# Altium schematic sheet Sonic Sandbox.SchDoc
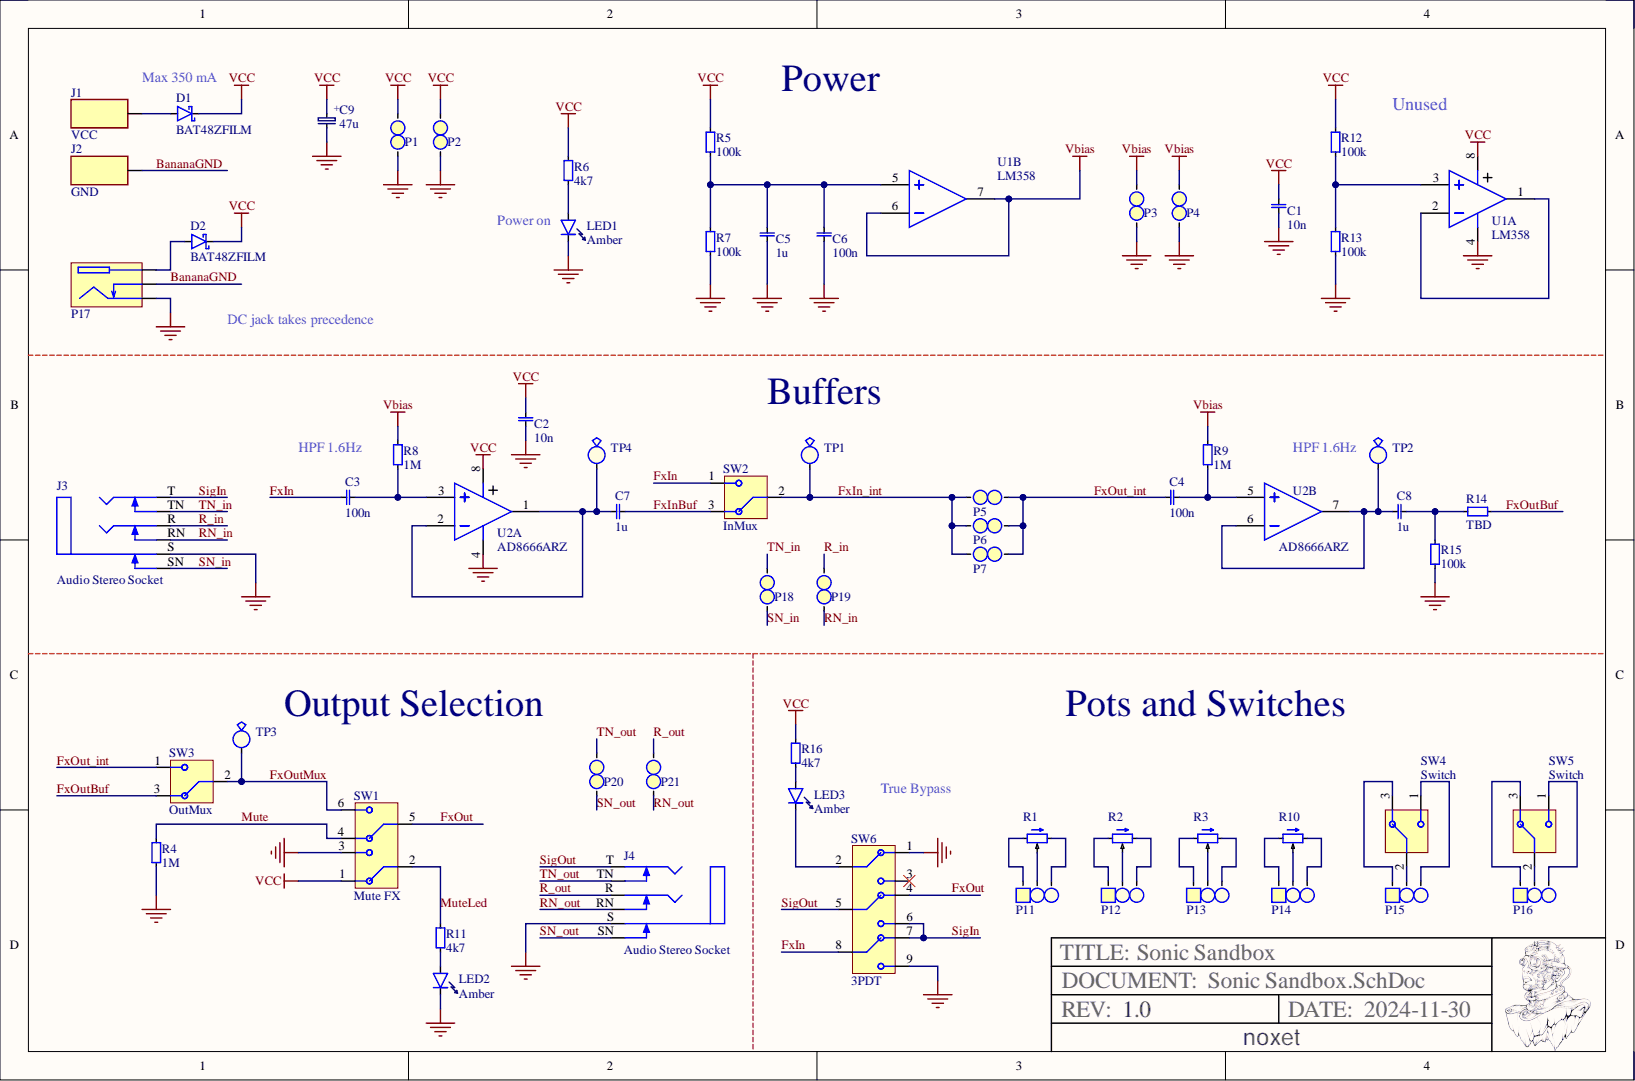
[diagram p0: Sonic Sandbox.SchDoc]

## Parts
C1  value 10n  [0805, cap]  (hidden comment: 0805, capacitor)
C2  value 10n  [0805, cap]  (hidden comment: 0805, capacitor)
C3  value 100n  [0805, cap]  (hidden comment: 0805, capacitor)
C4  value 100n  [0805, cap]  (hidden comment: 0805, capacitor)
C5  value 1u  [0805, cap]  (hidden comment: 0805, capacitor)
C6  value 100n  [0805, cap]  (hidden comment: 0805, capacitor)
C7  value 1u  [0805, cap]  (hidden comment: 0805, capacitor)
C8  value 1u  [0805, cap]  (hidden comment: 0805, capacitor)
C9  value 47u  [Cap, SMD, Polar, 5.3x5.3mm]  (hidden comment: Capacitor, TH 2mm)
D1  BAT48ZFILM  [SOD123]
D2  BAT48ZFILM  [SOD123]
J1  VCC  [Banana Conn VCC]
J2  GND  [Banana Conn GND]
J3  Audio Stereo Socket  [6.3mm Audio Socket PCB]
J4  Audio Stereo Socket  [6.3mm Audio Socket PCB]
LED1  Amber  [0805_led]
LED2  Amber  [0805_led]
LED3  Amber  [0805_led]
P1  1x2 Pin Heade Straight  [padtwo_L]  (value not drawn)
P2  1x2 Pin Heade Straight  [padtwo_L]  (value not drawn)
P3  1x2 Pin Heade Straight  [padtwo_L]  (value not drawn)
P4  1x2 Pin Heade Straight  [padtwo_L]  (value not drawn)
P5  1x2 Pin Heade Straight  [padtwo_L]  (value not drawn)
P6  1x2 Pin Heade Straight  [padtwo_L]  (value not drawn)
P7  1x2 Pin Heade Straight  [padtwo_L]  (value not drawn)
P11  1x3 Pin Header  [padThree_L]  (value not drawn)
P12  1x3 Pin Header  [padThree_L]  (value not drawn)
P13  1x3 Pin Header  [padThree_L]  (value not drawn)
P14  1x3 Pin Header  [padThree_L]  (value not drawn)
P15  1x3 Pin Header  [padThree_L]  (value not drawn)
P16  1x3 Pin Header  [padThree_L]  (value not drawn)
P17  Power socket  [DC Plug PCB]  (value not drawn)
P18  1x2 Pin Heade Straight  [padtwo_L]  (value not drawn)
P19  1x2 Pin Heade Straight  [padtwo_L]  (value not drawn)
P20  1x2 Pin Heade Straight  [padtwo_L]  (value not drawn)
P21  1x2 Pin Heade Straight  [padtwo_L]  (value not drawn)
R1  Potentiometer  [RK09L_15mm]  (value not drawn)
R2  Potentiometer  [RK09L_15mm]  (value not drawn)
R3  Potentiometer  [RK09L_15mm]  (value not drawn)
R4  value 1M  [0805]  (hidden comment: General resistor)
R5  value 100k  [0805]  (hidden comment: General resistor)
R6  value 4k7  [0805]  (hidden comment: General resistor)
R7  value 100k  [0805]  (hidden comment: General resistor)
R8  value 1M  [0805]  (hidden comment: General resistor)
R9  value 1M  [0805]  (hidden comment: General resistor)
R10  Potentiometer  [RK09L_15mm]  (value not drawn)
R11  value 4k7  [0805]  (hidden comment: General resistor)
R12  value 100k  [0805]  (hidden comment: General resistor)
R13  value 100k  [0805]  (hidden comment: General resistor)
R14  value TBD  [0805]  (hidden comment: General resistor)
R15  value 100k  [0805]  (hidden comment: General resistor)
R16  value 4k7  [0805]  (hidden comment: General resistor)
SW1  Mute FX  [SW_DPDT_MTS-202-A2]
SW2  InMux  [SW_SPDT_SMTS-102-A2]
SW3  OutMux  [SW_SPDT_SMTS-102-A2]
SW4  Switch  [SW_SPDT_SMTS-102-A2]
SW5  Switch  [SW_SPDT_SMTS-102-A2]
SW6  3PDT  [SW_3PDT_PBS-24-302]
TP1  Test Point  [TESTPOINT_SMD]  (value not drawn)
TP2  Test Point  [TESTPOINT_SMD]  (value not drawn)
TP3  Test Point  [TESTPOINT_SMD]  (value not drawn)
TP4  Test Point  [TESTPOINT_SMD]  (value not drawn)
U1  LM358  [SOIC8]  (parts A,B)
U2  AD8666ARZ  [SOIC8]  (parts A,B)

## Nets
GND: C1.2 C2.2 C5.2 C6.2 C9.1 J3.S J4.S LED1.0 LED2.0 P1.2 P2.2 P3.2 P4.2 P17.2 R4.1 R7.1 R13.1 R15.2 SW1.3 SW6.1 SW6.9 U1.4 U2.4
Vbias: P3.1 P4.1 R8.2 R9.2 U1.6 U1.7
VCC: C1.1 C2.1 C9.2 D1.1 D2.1 P1.1 P2.1 R5.2 R6.2 R12.2 R16.2 SW1.1 U1.8 U2.8
BananaGND: J2.1 P17.3
FxIn: C3.2 SW2.1 SW6.8
FxIn_int: P5.2 P6.2 P7.2 SW2.2 TP1.0
FxInBuf: C7.1 SW2.3
FxOut: SW1.5 SW6.4
FxOut_int: C4.2 P5.1 P6.1 P7.1 SW3.1
FxOutBuf: R14.1 SW3.3
FxOutMux: SW1.6 SW3.2 TP3.0
Mute: R4.2 SW1.4
MuteLed: R11.2 SW1.2
R_in: J3.R P19.1
R_out: J4.R P21.1
RN_in: J3.RN P19.2
RN_out: J4.RN P21.2
SigIn: J3.T SW6.6 SW6.7
SigOut: J4.T SW6.5
SN_in: J3.SN P18.2
SN_out: J4.SN P20.2
TN_in: J3.TN P18.1
TN_out: J4.TN P20.1
NetC3_1: C3.1 R8.1 U2.3
NetC4_1: C4.1 R9.1 U2.5
NetC5_1: C5.1 C6.1 R5.1 R7.2 U1.5
NetC7_2: C7.2 TP4.0 U2.1 U2.2
NetC8_1: C8.1 R14.2 R15.1
NetC8_2: C8.2 TP2.0 U2.6 U2.7
NetD1_2: D1.2 J1.1
NetD2_2: D2.2 P17.1
NetLED1_1: LED1.1 R6.1
NetLED2_1: LED2.1 R11.1
NetLED3_0: LED3.0 SW6.2
NetLED3_1: LED3.1 R16.1
NetP11_1: P11.1 R1.1
NetP11_2: P11.2 R1.2
NetP11_3: P11.3 R1.3
NetP12_1: P12.1 R2.1
NetP12_2: P12.2 R2.2
NetP12_3: P12.3 R2.3
NetP13_1: P13.1 R3.1
NetP13_2: P13.2 R3.2
NetP13_3: P13.3 R3.3
NetP14_1: P14.1 R10.1
NetP14_2: P14.2 R10.2
NetP14_3: P14.3 R10.3
NetP15_1: P15.1 SW4.3
NetP15_2: P15.2 SW4.2
NetP15_3: P15.3 SW4.1
NetP16_1: P16.1 SW5.3
NetP16_2: P16.2 SW5.2
NetP16_3: P16.3 SW5.1
NetR12_1: R12.1 R13.2 U1.3
NetU1_1: U1.1 U1.2

Not connected: SW6 3

## Library data of the parts
C9 = Capacitor, TH 2mm: Capacitor TH 2mm; Component Kind: Standard; Library Name: jclib.IntLib
D1 D2 = BAT48ZFILM: Rectifier Diode, Schottky, 1 Phase, 1 Element, 0.35A, 40V V(RRM), Silicon; Manufacturer: STMicroelectronics; Forward Voltage: 250mV; Max Output current: 350mA; Non-rep Pk Forward Current-Max: 2A; Number of Elements: 1; Package Body Material: Plastic; Qualification Status: Not Qualified; Time @ Peak Reflow Temperature-Max (s): 30s; Category: Rectifier Diodes; Component Kind: Standard; ComponentLink1Description: https://datasheet.ciiva.com:443/pdfs/VipMasterIC/IC/SGST/SGSTS30425/SGSTS30425-1.pdf?src-supplier=IHS+Markit; ComponentLink1URL: https://datasheet.ciiva.com:443/pdfs/VipMasterIC/IC/SGST/SGSTS30425/SGSTS30425-1.pdf?src-supplier=IHS+Markit; ComponentLink2Description: https://datasheet.ciiva.com/16421/cd00130229-16421639.pdf?src-supplier=Future+Electronics; ComponentLink2URL: https://datasheet.ciiva.com/16421/cd00130229-16421639.pdf?src-supplier=Future+Electronics; ComponentLink3Description: https://datasheet.ciiva.com/915/cd00130229-915584.pdf?src-supplier=Digikey; ComponentLink3URL: https://datasheet.ciiva.com/915/cd00130229-915584.pdf?src-supplier=Digikey; ComponentLink4Description: https://datasheet.ciiva.com/915/cd00130229-915585.pdf?src-supplier=Digikey; ComponentLink4URL: https://datasheet.ciiva.com/915/cd00130229-915585.pdf?src-supplier=Digikey; ComponentLink5Description: https://datasheet.ciiva.com/915/cd00130229-915586.pdf?src-supplier=Digikey; ComponentLink5URL: https://datasheet.ciiva.com/915/cd00130229-915586.pdf?src-supplier=Digikey; ComponentLink6Description: https://datasheet.ciiva.com/4714/cd00130229-4714093.pdf?src-supplier=Mouser; ComponentLink6URL: https://datasheet.ciiva.com/4714/cd00130229-4714093.pdf?src-supplier=Mouser; Diode Element Material: SILICON; Diode Type: RECTIFIER; JESD-30 Code: R-PDSO-G2; JESD-609 Code: e3; Manufacturer Part Number: BAT48ZFILM; Max Operating Temperature: 150°C; Package Shape: Rectangular; Package Style: SMALL OUTLINEMeter; Peak Reflow Temperature (Cel): 260°C; Pins: 2; Pricing: 250=2,567, 500=2,311, 1250=2,081, 2500=1,976 (SEK); Reach SVHC Compliant: No; Rep Pk Reverse Voltage-Max: 40V; RoHS: Yes; RoHS Compliant: Yes; Stock: 13200; Supplier: Rs; Supplier Part Number: 7146853P; Surface Mount: Yes; Technology: SCHOTTKY; Terminal Finish: Tin; Terminal Form: Gull Wing; Terminal Position: DUAL; Library Name: jclib.IntLib
J1 J2 = Banana conn: Banana Connector 571-0100; Manufacturer_Name: Deltron; Component Kind: Standard; Datasheet Link: https://www.dem-uk.com/deltron-components/Data/Product_Downloads/deltron-571-0100-4mm-90deg-single-socket-data.pdf; Height: 12.4mm; Manufacturer_Part_Number: 571-0100; Mouser Part Number: 164-6218; Mouser Price/Stock: https://www.mouser.com/Search/Refine.aspx?Keyword=164-6218; Library Name: jclib.IntLib
J3 J4 = 6.3mm Audio Socket: Component Kind: Standard; Library Name: jclib.IntLib
LED1-LED3 = 0805, LED: Value: 0805 LED; Component Kind: Standard; Library Name: jclib.IntLib
P1-P7 P18-P21 = 1x2 Pin Heade Straight: 2.54 mm, TH; Value: 1x2 Pin Header; Component Kind: Standard; Library Name: jclib.IntLib
P11-P16 = 1x3 Pin Header: 2.54 mm; Component Kind: Standard; Value: 1x3 Pin Header; Library Name: jclib.IntLib
R1-R3 R10 = Potentiometer: Value: 0; Component Kind: Standard; Description: Potentiometer; ELFA: 300-08-353; Library Name: jclib.SchLib
R4-R9 R11-R16 = resistor: Component Kind: Standard; Library Name: jclib.IntLib
SW1 = Switch DPDT: Toggle switch DPDT; Component Kind: Standard; Library Name: jclib.IntLib
SW2-SW5 = Switch SPDT: Toggle switch SPDT; Component Kind: Standard; Library Name: jclib.IntLib
SW6 = Switch 3PDT: Toggle switch 3PDT; Component Kind: Standard; Library Name: jclib.IntLib
U1 = LM358DR: IC OPAMP GP 700KHZ 8SOIC; Manufacturer: Texas Instruments; Amplifier Type: GENERAL PURPOSE; Common Mode Rejection Ratio: 65dB; Dual Supply Voltage: 9V; Input Bias Current: 250nA; Input Offset Voltage (Vos): 7mV; Max Dual Supply Voltage: 16V; Max Supply Voltage: 32V; Min Dual Supply Voltage: 1.5V; Min Supply Voltage: 3V; Nominal Supply Current: 1.2mA; Number of Amplifiers: 2; Operating Supply Current: 1mA; Output Current: 30mA; Slew Rate: 0.3V/µs; Voltage Gain: 100dB; Bandwidth: 700kHz; Case/Package: SOIC; Category: Amplifiers - Op Amps, Buffer, Instrumentation; Component Kind: Standard; ComponentLink1Description: https://datasheet.ciiva.com/1795/lm358-1795488.pdf?src-supplier=Digi-Key; ComponentLink1URL: https://datasheet.ciiva.com/1795/lm358-1795488.pdf?src-supplier=Digi-Key; ComponentLink2Description: https://datasheet.ciiva.com/27056/2016330-27056228.pdf?src-supplier=Jameco; ComponentLink2URL: https://datasheet.ciiva.com/27056/2016330-27056228.pdf?src-supplier=Jameco; ComponentLink3Description: https://datasheet.ciiva.com/7709/1874566-7709823.pdf?src-supplier=Element14; ComponentLink3URL: https://datasheet.ciiva.com/7709/1874566-7709823.pdf?src-supplier=Element14; ComponentLink4Description: https://datasheet.ciiva.com/46385/1874566-46385851.pdf?src-supplier=Element14; ComponentLink4URL: https://datasheet.ciiva.com/46385/1874566-46385851.pdf?src-supplier=Element14; ComponentLink5Description: https://datasheet.ciiva.com/46390/1874566-46390414.pdf?src-supplier=Element14; ComponentLink5URL: https://datasheet.ciiva.com/46390/1874566-46390414.pdf?src-supplier=Element14; ComponentLink6Description: https://datasheet.ciiva.com/1795/lm358-1795487.pdf?src-supplier=Digi-Key; ComponentLink6URL: https://datasheet.ciiva.com/1795/lm358-1795487.pdf?src-supplier=Digi-Key; ComponentLink7Description: https://datasheet.ciiva.com/1795/lm358-1795489.pdf?src-supplier=Digi-Key; ComponentLink7URL: https://datasheet.ciiva.com/1795/lm358-1795489.pdf?src-supplier=Digi-Key; ComponentLink8Description: https://datasheet.ciiva.com/2973/lm358a-2973386.pdf?src-supplier=Avnet; ComponentLink8URL: https://datasheet.ciiva.com/2973/lm358a-2973386.pdf?src-supplier=Avnet; Contact Plating: Gold; Gain Bandwidth Product: 700kHz; Height: 1.58mm; Lead Free: Lead Free; Length: 4.9mm; Manufacturer Part Number: LM358DR; Max Operating Temperature: 70°C; Min Operating Temperature: 0°C; Mounting Technology: Surface Mount; Number of Channels: 2; Number of Circuits: 2; Number of Elements: 2; Operating Supply Voltage: 16V; Output Current per Channel: 30mA; Package Quantity: 2500; Packaging: Tape and Reel; Pins: 8; Power Supply Rejection Ratio (PSRR): 65dB; Pricing 1: 1=0,37000, 10=0,29900, 50=0,29900, 100=0,18500, 1000=0,11500, 10000=0,10400 (USD); Radiation Hardening: No; Reach SVHC Compliant: Yes; RoHS: Yes; RoHS Compliant: Yes; Series: -; Stock 1: 281120; Supplier 1: Mouser; Supplier Part Number 1: 595-LM358DR; Supply Current: 1.2mA; Technology: BIPOLAR; Unity Gain Bandwidth Product: 700kHz; Width: 3.91mm; Library Name: jclib.IntLib
U2 = AD8666ARZ: Operational Amplifier, 2 Func, 5000uV Offset-Max, CMOS, PDSO8; Manufacturer: Analog Devices; Architecture: VOLTAGE-FEEDBACK; Average Bias Current-Max (IIB): 550pA; Bias Current-Max (IIB) @25C: 1pA; Channels: 2; Common-mode Reject Ratio-Nom: 100dB; Frequency Compensation: YES; Input Offset Voltage-Max: 5mV; Low-Offset: No; Max Supply Current: 4mA; Neg Supply Voltage Limit-Max: 0V; Nominal Supply Voltage: 5V; Package Body Material: Plastic; Package Equivalence Code: SOP8,.25; Power Supplies: +-2.5/+-8/5/16V; Qualification Status: Not Qualified; Slew Rate: 3.5V/µs; Supply Voltage Limit-Max: 18V; Time @ Peak Reflow Temperature-Max (s): 30s; Unity Gain BW-Nom: 4MHz; Voltage Gain-Min: 68000; Amplifier Type: OPERATIONAL AMPLIFIER; Case/Package: SOP; Category: Amplifiers - Op Amps, Buffer, Instrumentation; Component Kind: Standard; ComponentLink1Description: https://datasheet.ciiva.com:443/pdfs/VipMasterIC/IC/ANDI/ANDIS11402/ANDIS11402-1.pdf?src-supplier=IHS+Markit; ComponentLink1URL: https://datasheet.ciiva.com:443/pdfs/VipMasterIC/IC/ANDI/ANDIS11402/ANDIS11402-1.pdf?src-supplier=IHS+Markit; ComponentLink2Description: https://datasheet.ciiva.com/1487/1481546-1487312.pdf?src-supplier=Element14; ComponentLink2URL: https://datasheet.ciiva.com/1487/1481546-1487312.pdf?src-supplier=Element14; ComponentLink3Description: https://datasheet.ciiva.com/2974/ad8665-ad8666-ad8668-2974734.pdf?src-supplier=Avnet; ComponentLink3URL: https://datasheet.ciiva.com/2974/ad8665-ad8666-ad8668-2974734.pdf?src-supplier=Avnet; ComponentLink4Description: https://datasheet.ciiva.com/1794/ad8665-ad8666-ad8668-1794389.pdf?src-supplier=Digikey; ComponentLink4URL: https://datasheet.ciiva.com/1794/ad8665-ad8666-ad8668-1794389.pdf?src-supplier=Digikey; ComponentLink5Description: https://datasheet.ciiva.com/48705/001249492-da-01-en-ic-opamp-gp-r-r-4mh-ad8666arz-soic-8-adi-48705820.pdf?src-supplier=Conrad; ComponentLink5URL: https://datasheet.ciiva.com/48705/001249492-da-01-en-ic-opamp-gp-r-r-4mh-ad8666arz-soic-8-adi-48705820.pdf?src-supplier=Conrad; Height - Seated (Max): 1.75mm; JESD-30 Code: R-PDSO-G8; JESD-609 Code: e3; Length: 4.9mm; Low-Bias: Yes; Manufacturer Part Number: AD8666ARZ; Max Operating Temperature: 125°C; Micropower: No; Min Operating Temperature: -40°C; Moisture Sensitivity Level: 1; Neg Supply Voltage-Nom (Vsup): 0V; Package Shape: Rectangular; Package Style: SMALL OUTLINEMeter; Peak Reflow Temperature (Cel): 260°C; Pins: 8; Power: No; Pricing: 1=2,83, 10=1,96, 50=1,96, 100=1,63, 1000=1,63, 10000=1,63 (USD); Programmable Power: No; Reach SVHC Compliant: Yes; RoHS: Yes; RoHS Compliant: Yes; Stock: 1754; Supplier: Mouser; Supplier Part Number: 584-AD8666ARZ; Surface Mount: Yes; Technology: CMOS; Temperature Grade: AUTOMOTIVE; Terminal Finish: Tin; Terminal Form: Gull Wing; Terminal Pitch: 1.27mm; Terminal Position: DUAL; Wideband: No; Width: 3.9mm; Library Name: jclib.IntLib
C1-C8 = 0805, capacitor: Component Kind: Standard; Library Name: jclib.IntLib
P17 = Power socket: Component Kind: Standard; Library Name: jclib.SchLib
TP1-TP4 = Test Point: Component Kind: Standard; Farnell: 1702006; Value: Test point; Library Name: jclib.IntLib
